# Altium schematic sheet Lights.SchDoc(Lights)
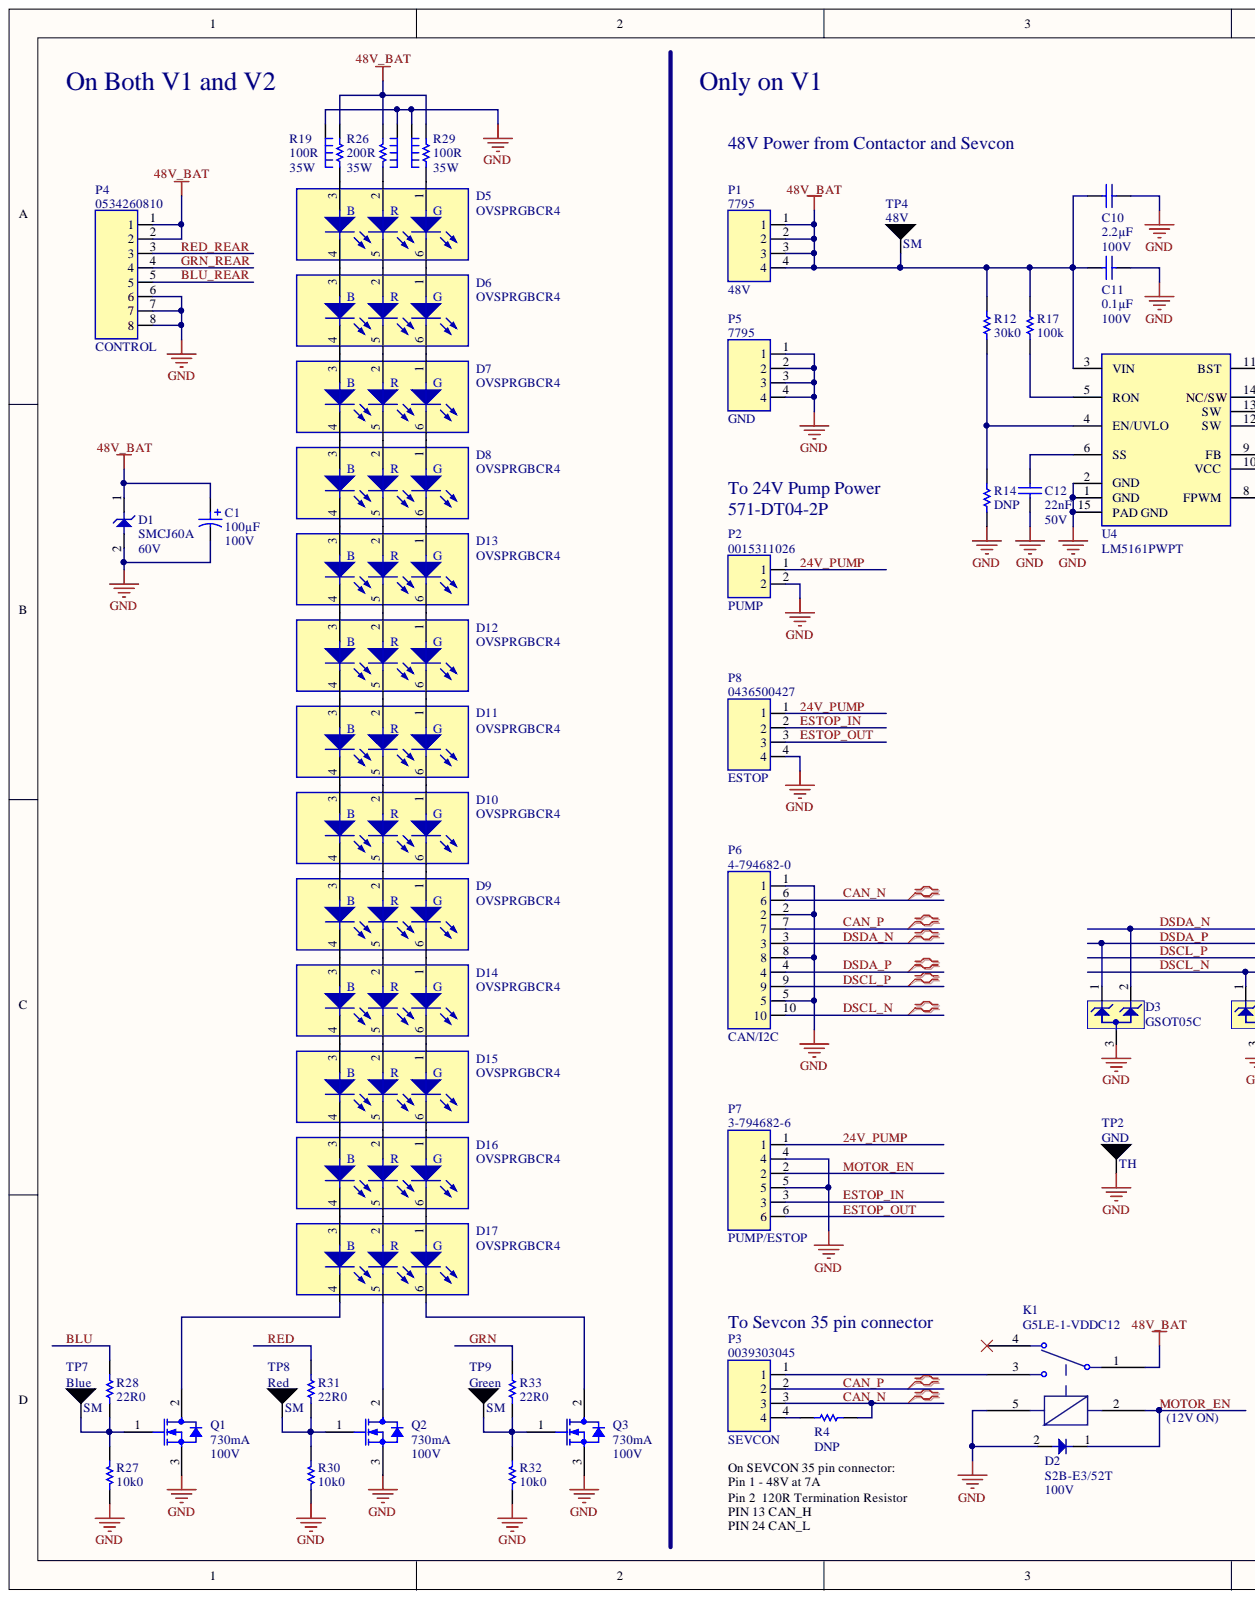
[diagram p0: Lights.SchDoc, left]
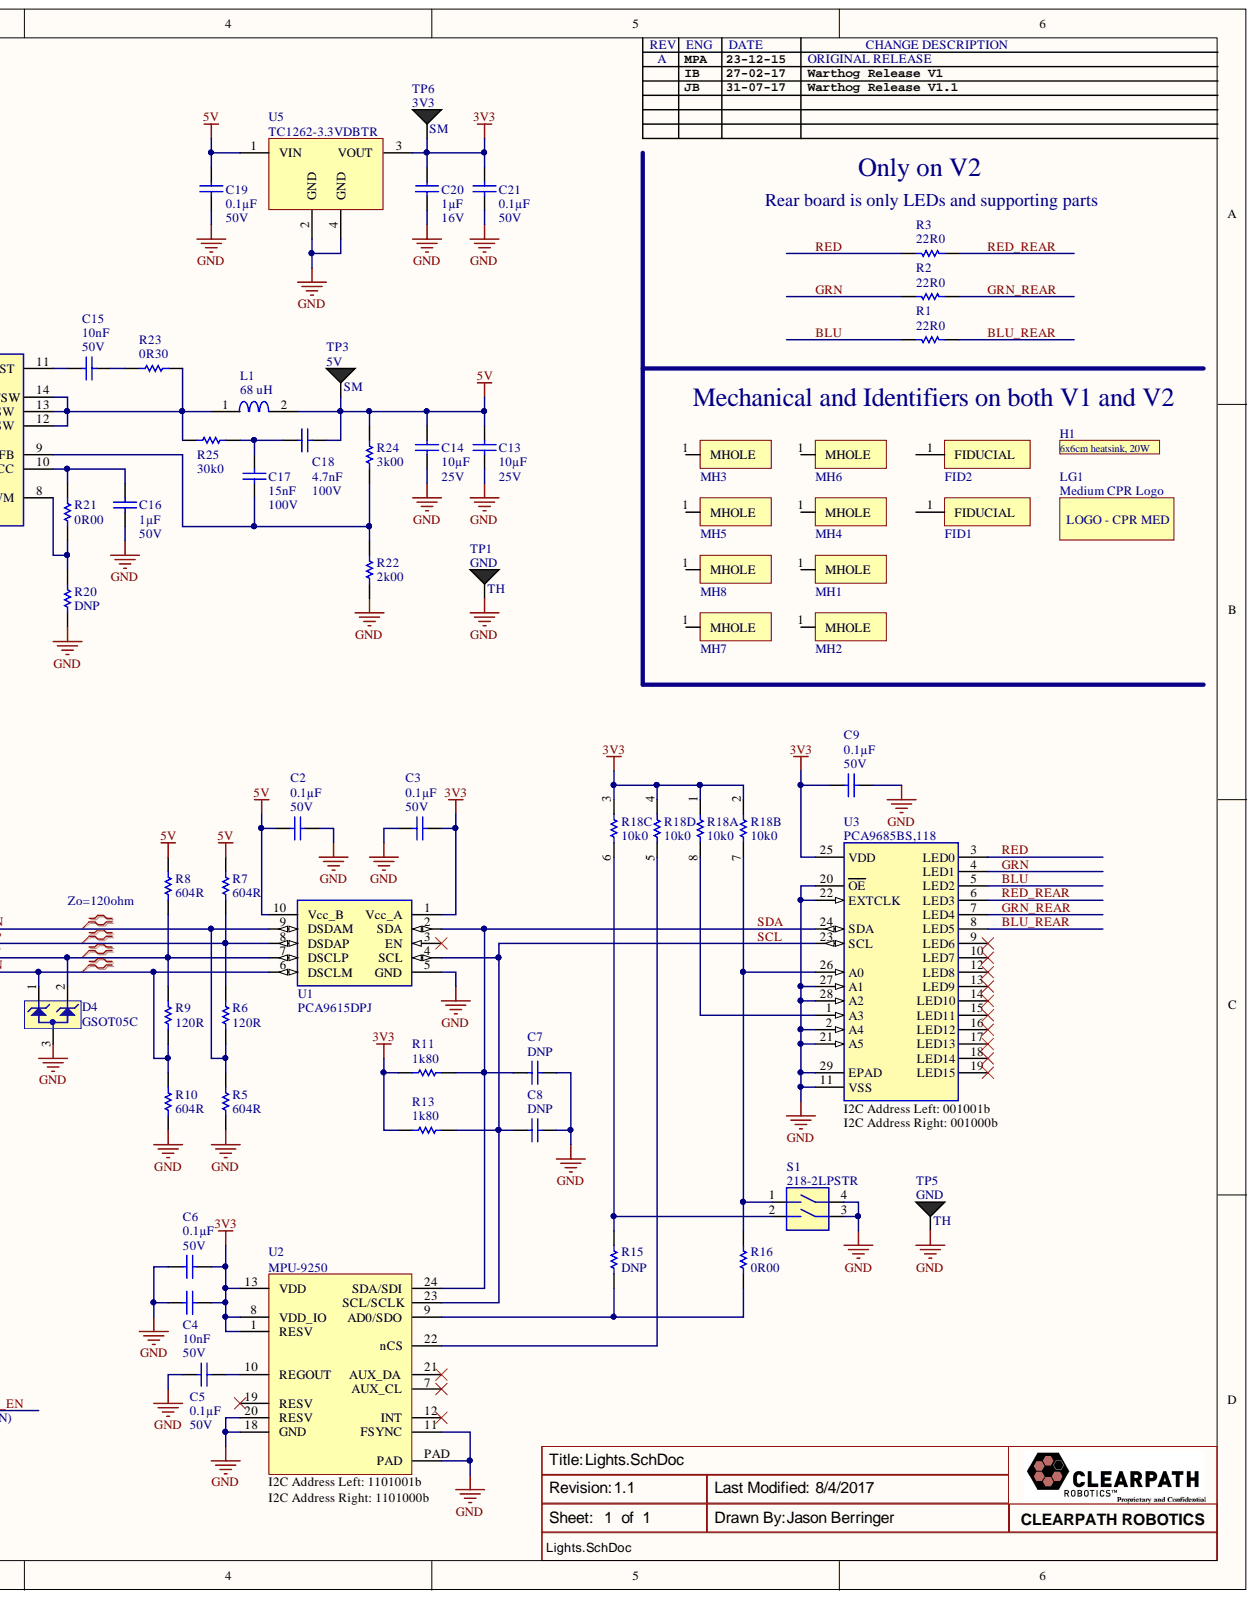
[diagram p1: Lights.SchDoc, right]

## Parts
C1  Voltage: 100V  Capacitance: 100µF  [PCAP KE0]  (hidden comment: Pcap)
C2  Voltage: 50V  Capacitance: 0.1µF  [CAP 0603]  (hidden comment: Cap)
C3  Voltage: 50V  Capacitance: 0.1µF  [CAP 0603]  (hidden comment: Cap)
C4  Capacitance: 10nF  [CAP 0603]  (hidden comment: Cap)
C5  Voltage: 50V  Capacitance: 0.1µF  [CAP 0603]  (hidden comment: Cap)
C6  Voltage: 50V  Capacitance: 0.1µF  [CAP 0603]  (hidden comment: Cap)
C7  Cap  [CAP 0603]  (value not drawn)
C8  Cap  [CAP 0603]  (value not drawn)
C9  Voltage: 50V  Capacitance: 0.1µF  [CAP 0603]  (hidden comment: Cap)
C10  Capacitance: 2.2µF  [CAP 1206]  (hidden comment: Cap)
C11  Voltage: 100V  Capacitance: 0.1µF  [CAP 0805]  (hidden comment: Cap)
C12  Voltage: 50V  Capacitance: 22nF  [CAP 0603]  (hidden comment: Cap)
C13  Voltage: 25V  Capacitance: 10µF  [CAP 1206]  (hidden comment: Cap)
C14  Voltage: 25V  Capacitance: 10µF  [CAP 1206]  (hidden comment: Cap)
C15  Voltage: 50V  Capacitance: 10nF  [CAP 0603]  (hidden comment: Cap)
C16  Voltage: 50V  Capacitance: 1µF  [CAP 0805]  (hidden comment: Cap)
C17  Voltage: 100V  Capacitance: 15nF  [CAP 0805]  (hidden comment: Cap)
C18  Voltage: 100V  Capacitance: 4.7nF  [CAP 0805]  (hidden comment: Cap)
C19  Voltage: 50V  Capacitance: 0.1µF  [CAP 0603]  (hidden comment: Cap)
C20  Voltage: 16V  Capacitance: 1µF  [CAP 0603]  (hidden comment: Cap)
C21  Voltage: 50V  Capacitance: 0.1µF  [CAP 0603]  (hidden comment: Cap)
D1  Voltage: 60V  [SMC_DO-214AB]  (hidden comment: TVS Diode)
D2  Voltage: 100V  [SMB_DO-214AA]  (hidden comment: Diode)
D3  GSOT05C  [SOT-23-3]
D4  GSOT05C  [SOT-23-3]
D5  OVSPRGBCR4  [OVSPRGBCR4]
D6  OVSPRGBCR4  [OVSPRGBCR4]
D7  OVSPRGBCR4  [OVSPRGBCR4]
D8  OVSPRGBCR4  [OVSPRGBCR4]
D9  OVSPRGBCR4  [OVSPRGBCR4]
D10  OVSPRGBCR4  [OVSPRGBCR4]
D11  OVSPRGBCR4  [OVSPRGBCR4]
D12  OVSPRGBCR4  [OVSPRGBCR4]
D13  OVSPRGBCR4  [OVSPRGBCR4]
D14  OVSPRGBCR4  [OVSPRGBCR4]
D15  OVSPRGBCR4  [OVSPRGBCR4]
D16  OVSPRGBCR4  [OVSPRGBCR4]
D17  OVSPRGBCR4  [OVSPRGBCR4]
FID1  Fiducial-1mm  [Fiducial-1mm]  (value not drawn)
FID2  Fiducial-1mm  [Fiducial-1mm]  (value not drawn)
H1  Component_1  [ATS-60003-C1-R0]  (value not drawn)
K1  G5LE-1-VDDC12  [G5LE-1-VDDC12]
L1  Inductance: 68 uH  [SRP7050TA-680M]  (hidden comment: Ind)
LG1  Medium CPR Logo  [Medium]
MH1  MOUNT_HOLE  [5.2mm mounting hole]  (value not drawn)
MH2  MOUNT_HOLE  [5.2mm mounting hole]  (value not drawn)
MH3  MOUNT_HOLE  [5.2mm mounting hole]  (value not drawn)
MH4  MOUNT_HOLE  [5.2mm mounting hole]  (value not drawn)
MH5  MOUNT_HOLE  [5.2mm mounting hole]  (value not drawn)
MH6  MOUNT_HOLE  [5.2mm mounting hole]  (value not drawn)
MH7  MOUNT_HOLE  [5.2mm mounting hole]  (value not drawn)
MH8  MOUNT_HOLE  [5.2mm mounting hole]  (value not drawn)
P1  48V  [7795]
P2  PUMP  [0015311026]
P3  SEVCON  [0039303045]
P4  CONTROL  [0534260810]
P5  GND  [7795]
P6  CAN/I2C  [4-794682-0]
P7  PUMP/ESTOP  [3-794682-6]
P8  ESTOP  [0436500427]
Q1  Voltage: 100V  Current: 730mA  [SOT89]  (hidden comment: MOSFET_SOT89)
Q2  Voltage: 100V  Current: 730mA  [SOT89]  (hidden comment: MOSFET_SOT89)
Q3  Voltage: 100V  Current: 730mA  [SOT89]  (hidden comment: MOSFET_SOT89)
R1  Resistance: 22R0  [RES 0603]  (hidden comment: Res)
R2  Resistance: 22R0  [RES 0603]  (hidden comment: Res)
R3  Resistance: 22R0  [RES 0603]  (hidden comment: Res)
R4  Res  [RES 0603]
R5  Resistance: 604R  [RES 0603]  (hidden comment: Res)
R6  Resistance: 120R  [RES 0603]  (hidden comment: Res)
R7  Resistance: 604R  [RES 0603]  (hidden comment: Res)
R8  Resistance: 604R  [RES 0603]  (hidden comment: Res)
R9  Resistance: 120R  [RES 0603]  (hidden comment: Res)
R10  Resistance: 604R  [RES 0603]  (hidden comment: Res)
R11  Resistance: 1k80  [RES 0603]  (hidden comment: Res)
R12  Resistance: 30k0  [RES 0603]  (hidden comment: Res)
R13  Resistance: 1k80  [RES 0603]  (hidden comment: Res)
R14  Res  [RES 0603]  (value not drawn)
R15  Res  [RES 0603]  (value not drawn)
R16  Resistance: 0R00  [RES 0603]  (hidden comment: Res)
R17  Resistance: 100k  [RES 0603]  (hidden comment: Res)
R18  Resistance: 10k0  [RESPACK 0804]  (hidden comment: Respack)  (parts A,B,C,D)
R19  Resistance: 100R  Power: 35W  [PWR263S-35]  (hidden comment: Res_D2PAK)
R20  Res  [RES 0603]  (value not drawn)
R21  Resistance: 0R00  [RES 0603]  (hidden comment: Res)
R22  Resistance: 2k00  [RES 0603]  (hidden comment: Res)
R23  Resistance: 0R30  [RES 0603]  (hidden comment: Res)
R24  Resistance: 3k00  [RES 0603]  (hidden comment: Res)
R25  Resistance: 30k0  [RES 0603]  (hidden comment: Res)
R26  Resistance: 200R  Power: 35W  [PWR263S-35]  (hidden comment: Res_D2PAK)
R27  Resistance: 10k0  [RES 0603]  (hidden comment: Res)
R28  Resistance: 22R0  [RES 0603]  (hidden comment: Res)
R29  Resistance: 100R  Power: 35W  [PWR263S-35]  (hidden comment: Res_D2PAK)
R30  Resistance: 10k0  [RES 0603]  (hidden comment: Res)
R31  Resistance: 22R0  [RES 0603]  (hidden comment: Res)
R32  Resistance: 10k0  [RES 0603]  (hidden comment: Res)
R33  Resistance: 22R0  [RES 0603]  (hidden comment: Res)
S1  218-2LPSTR  [218-2LPSTR]
TP1  GND  [TP_5028TH]
TP2  GND  [TP_5028TH]
TP3  5V  [TP_28SM]
TP4  48V  [TP_28SM]
TP5  GND  [TP_5028TH]
TP6  3V3  [TP_28SM]
TP7  Blue  [TP_28SM]
TP8  Red  [TP_28SM]
TP9  Green  [TP_28SM]
U1  PCA9615DPJ  [TSSOP-10N]
U2  MPU-9250  [QFN24 - 3x3x0.9 EXP PAD]
U3  PCA9685BS  [HVQFN-28-EP]
U4  LM5161PWPT  [TSSOP-14N-EP]
U5  PCB Component: TC1262-3.3VDBTR  [SOT223]  (hidden comment: FIX REGULATOR SOT223)

## Nets
3V3: C3.1 C4.1 C6.1 C9.1 C20.2 C21.2 R11.1 R13.1 R18.1 R18.2 R18.3 R18.4 TP6.1 U1.1(Vcc_A) U2.1(RESV) U2.8(VDD_IO) U2.13(VDD) U3.25(VDD) U5.3(VOUT)
5V: C2.1 C13.2 C14.2 C18.2 C19.2 L1.2 R7.2 R8.2 R24.1 TP3.1 U1.10(Vcc_B) U5.1(VIN)
48V_BAT: C1.1 C10.2 C11.1 D1.1 K1.1 P1.1 P1.2 P1.3 P1.4 P4.1 P4.2 R12.2 R17.2 R19.1 R26.1 R29.1 TP4.1 U4.3(VIN)
GND: C1.2 C2.2 C3.2 C4.2 C5.2 C6.2 C7.1 C8.1 C9.2 C10.1 C11.2 C12.1 C13.1 C14.1 C16.1 C19.1 C20.1 C21.1 D1.2 D2.2 D3.3 D4.3 K1.5 P2.2 P4.6 P4.7 P4.8 P5.1 P5.2 P5.3 P5.4 P6.1 P6.2 P6.5 P6.8 P7.4 P7.5 P8.4 Q1.3 Q2.3 Q3.3 R5.1 R10.1 R14.1 R19.3 R20.1 R22.2 R26.3 R27.2 R29.3 R30.2 R32.2 S1.3 S1.4 TP1.1 TP2.1 TP5.1 U1.5(GND) U2.11(FSYNC) U2.18(GND) U2.20(RESV) U2.PAD U3.2(A4) U3.11(VSS) U3.20(OE) U3.21(A5) U3.22(EXTCLK) U3.27(A1) U3.28(A2) U3.29(EPAD) U4.1(GND) U4.2(GND) U4.15(PAD_GND) U5.2(GND) U5.4(GND)
24V_PUMP: P2.1 P7.1 P8.1
BLU: R1.2 R28.2 U3.5(LED2)
BLU_REAR: P4.5 R1.1 U3.8(LED5)
CAN_N: P3.3 P6.6 R4.2
CAN_P: P3.2 P6.7
DSCL_N: D4.1 P6.10 R9.1 R10.2 U1.6(DSCLM)
DSCL_P: D4.2 P6.9 R8.1 R9.2 U1.7(DSCLP)
DSDA_N: D3.2 P6.3 R5.2 R6.1 U1.9(DSDAM)
DSDA_P: D3.1 P6.4 R6.2 R7.1 U1.8(DSDAP)
ESTOP_IN: P7.3 P8.2
ESTOP_OUT: P7.6 P8.3
GRN: R2.2 R33.2 U3.4(LED1)
GRN_REAR: P4.4 R2.1 U3.7(LED4)
MOTOR_EN: D2.1 K1.2 P7.2
RED: R3.2 R31.2 U3.3(LED0)
RED_REAR: P4.3 R3.1 U3.6(LED3)
SCL: C8.2 R13.2 U1.4(SCL) U2.23(SCL/SCLK) U3.23(SCL)
SDA: C7.2 R11.2 U1.2(SDA) U2.24(SDA/SDI) U3.24(SDA)
NetC5_1: C5.1 U2.10(REGOUT)
NetC12_2: C12.2 U4.6(SS)
NetC15_1: C15.1 R23.1
NetC15_2: C15.2 U4.11(BST)
NetC16_2: C16.2 R21.2 U4.10(VCC)
NetC17_1: C17.1 R22.1 R24.2 U4.9(FB)
NetC17_2: C17.2 C18.1 R25.2
NetD5_1: D5.1 R29.2
NetD5_2: D5.2 R26.2
NetD5_3: D5.3 R19.2
NetD5_4: D5.4 D6.3
NetD5_5: D5.5 D6.2
NetD5_6: D5.6 D6.1
NetD6_4: D6.4 D7.3
NetD6_5: D6.5 D7.2
NetD6_6: D6.6 D7.1
NetD7_4: D7.4 D8.3
NetD7_5: D7.5 D8.2
NetD7_6: D7.6 D8.1
NetD8_4: D8.4 D13.3
NetD8_5: D8.5 D13.2
NetD8_6: D8.6 D13.1
NetD9_1: D9.1 D10.6
NetD9_2: D9.2 D10.5
NetD9_3: D9.3 D10.4
NetD9_4: D9.4 D14.3
NetD9_5: D9.5 D14.2
NetD9_6: D9.6 D14.1
NetD10_1: D10.1 D11.6
NetD10_2: D10.2 D11.5
NetD10_3: D10.3 D11.4
NetD11_1: D11.1 D12.6
NetD11_2: D11.2 D12.5
NetD11_3: D11.3 D12.4
NetD12_1: D12.1 D13.6
NetD12_2: D12.2 D13.5
NetD12_3: D12.3 D13.4
NetD14_4: D14.4 D15.3
NetD14_5: D14.5 D15.2
NetD14_6: D14.6 D15.1
NetD15_4: D15.4 D16.3
NetD15_5: D15.5 D16.2
NetD15_6: D15.6 D16.1
NetD16_4: D16.4 D17.3
NetD16_5: D16.5 D17.2
NetD16_6: D16.6 D17.1
NetD17_4: D17.4 Q1.2
NetD17_5: D17.5 Q2.2
NetD17_6: D17.6 Q3.2
NetK1_3: K1.3 P3.1
NetL1_1: L1.1 R23.2 R25.1 U4.12(SW) U4.13(SW) U4.14(NC/SW)
NetP3_4: P3.4 R4.1
NetQ1_1: Q1.1 R27.1 R28.1 TP7.1
NetQ2_1: Q2.1 R30.1 R31.1 TP8.1
NetQ3_1: Q3.1 R32.1 R33.1 TP9.1
NetR12_1: R12.1 R14.2 U4.4(EN/UVLO)
NetR15_1: R15.1 R16.1 U2.9(AD0/SDO)
NetR15_2: R15.2 R18.6 S1.2
NetR16_2: R16.2 R18.7 S1.1 U3.26(A0)
NetR17_1: R17.1 U4.5(RON)
NetR18_5: R18.5 U2.22(nCS)
NetR18_8: R18.8 U3.1(A3)
NetR20_2: R20.2 R21.1 U4.8(FPWM)

Not connected: FID1 1; FID2 1; K1 4; MH1 1; MH2 1; MH3 1; MH4 1; MH5 1; MH6 1; MH7 1; MH8 1; U1 3(EN); U2 7(AUX_CL) 12(INT) 19(RESV) 21(AUX_DA); U3 9(LED6) 10(LED7) 12(LED8) 13(LED9) 14(LED10) 15(LED11) 16(LED12) 17(LED13) 18(LED14) 19(LED15)

## Library data of the parts
C1 = EMVY101ARA101MKE0S: Manufacturer: United Chemi-Con; Vendor PN: 565-2511-1-ND; Vendor: Digi-Key; Temperature Coefficient: -40 - 105C; ID: 29; Database Table Name: Capacitors; PCB Component: EMVY101ARA101MKE0S; Octopart Link: https://octopart.com/search?q=EMVY101ARA101MKE0S&start=0; Library Name: Parts.DbLib; Component Kind: Standard
C2 C3 C5 C6 C19 C21 = GRM188R71H104JA93D: Capacitor; Manufacturer: Murata Electronics North America; Vendor PN: 490-13406-1-ND; Vendor: Digi-Key; Temperature Coefficient: X7R; ID: 5; Database Table Name: Capacitors; PCB Component: GRM188R71H104JA93D; Library Name: Parts.DbLib; Component Kind: Standard
C4 = GRM188R71H103JA01J: Capacitor; Manufacturer: Murata Electronics North America; Voltage: 50V; Vendor PN: 490-11532-1-ND; Vendor: Digi-Key; Temperature Coefficient: X7R; ID: 6; Database Table Name: Capacitors; PCB Component: GRM188R71H103JA01J; Library Name: Parts.DbLib; Component Kind: Standard
C7 C8 = GRM188R71H221JA01D: Capacitor; Manufacturer: Murata Electronics North America; Voltage: 50V; Vendor PN: 490-12545-1-ND; Vendor: Digi-Key; Temperature Coefficient: X7R; ID: 8; Database Table Name: Capacitors; Capacitance: 220pF; PCB Component: GRM188R71H221JA01D; Library Name: Parts.DbLib; DNP: DNP; Component Kind: Standard
C9 = GRM188R71H104JA93D: Manufacturer: Murata Electronics North America; Vendor PN: 490-13406-1-ND; Vendor: Digi-Key; Temperature Coefficient: X7R; ID: 5; Database Table Name: Capacitors; PCB Component: GRM188R71H104JA93D; Octopart Link: https://octopart.com/search?q=GRM188R71H104JA93D&start=0; Library Name: Parts.DbLib; Component Kind: Standard
C10 = CC1206KKX7R0BB225: Manufacturer: Yageo; Voltage: 100V; Vendor PN: 311-3450-1-ND; Vendor: Digi-Key; Temperature Coefficient: X7R; ID: 32; Database Table Name: Capacitors; PCB Component: CC1206KKX7R0BB225; Library Name: Parts.DbLib; Component Kind: Standard
C11 = GRM21BR72A104KAC4L: Capacitor; Manufacturer: Murata Electronics North America; Vendor PN: 490-12005-1-ND; Vendor: Digi-Key; Temperature Coefficient: X7R; ID: 10; Database Table Name: Capacitors; PCB Component: GRM21BR72A104KAC4L; Library Name: Parts.DbLib; Component Kind: Standard
C12 = GRM188R71H223JA01D: Capacitor; Manufacturer: Murata Electronics North America; Vendor PN: 490-14377-1-ND; Vendor: Digi-Key; Temperature Coefficient: X7R; ID: 11; Database Table Name: Capacitors; PCB Component: GRM188R71H223JA01D; Library Name: Parts.DbLib; Component Kind: Standard
C13 C14 = GRM31CR71E106KA12L: Capacitor; Manufacturer: Murata Electronics North America; Vendor PN: 490-6518-1-ND; Vendor: Digi-Key; Temperature Coefficient: X7R; ID: 12; Database Table Name: Capacitors; PCB Component: GRM31CR71E106KA12L; Library Name: Parts.DbLib; Component Kind: Standard
C15 = GRM188R71H103JA01J: Capacitor; Manufacturer: Murata Electronics North America; Vendor PN: 490-11532-1-ND; Vendor: Digi-Key; Temperature Coefficient: X7R; ID: 6; Database Table Name: Capacitors; PCB Component: GRM188R71H103JA01J; Library Name: Parts.DbLib; Component Kind: Standard
C16 = CL21B105KBFNFNE: Capacitor; Manufacturer: Samsung Electro-Mechanics America, Inc.; Vendor PN: 1276-2928-1-ND; Vendor: Digi-Key; Temperature Coefficient: X7R; ID: 3; Database Table Name: Capacitors; PCB Component: CL21B105KBFNFNE; Library Name: Parts.DbLib; Component Kind: Standard
C17 = GRM21BR72A153KA01L: Capacitor; Manufacturer: Murata Electronics North America; Vendor PN: 490-1655-1-ND; Vendor: Digi-Key; Temperature Coefficient: X7R; ID: 14; Database Table Name: Capacitors; PCB Component: GRM21BR72A153KA01L; Library Name: Parts.DbLib; Component Kind: Standard
C18 = GRM219R72A472KA01D: Capacitor; Manufacturer: Murata Electronics North America; Vendor PN: 490-1646-1-ND; Vendor: Digi-Key; Temperature Coefficient: X7R; ID: 13; Database Table Name: Capacitors; PCB Component: GRM219R72A472KA01D; Library Name: Parts.DbLib; Component Kind: Standard
C20 = GRM188R61C105KA93D: Capacitor; Manufacturer: Murata Electronics North America; Vendor PN: 490-1536-1-ND; Vendor: Digi-Key; Temperature Coefficient: X7R; ID: 18; Database Table Name: Capacitors; PCB Component: GRM188R61C105KA93D; Library Name: Parts.DbLib; Component Kind: Standard
D1 = SMCJ60A: Manufacturer: Bourns Inc.; Vendor PN: SMCJ60ABCT-ND; Vendor: Digi-Key; ID: 11; Database Table Name: Circuit Protection; Current: 15.5A; Type: TVS; PCB Component: SMCJ60A; Octopart Link: https://octopart.com/search?q=SMCJ60A&start=0; Library Name: Parts.DbLib; Component Kind: Standard
D2 = S2B-E3/52T: Genral purpose diode 100V 1.5A; Manufacturer: Vishay Semiconductor Diodes Division; Vendor PN: S2B-E3/52TGICT-ND; Vendor: Digi-Key; ID: 10; Database Table Name: Diodes; Type: Diode; PCB Component: S2B-E3/52T; Octopart Link: https://octopart.com/search?q=S2B-E3%2F52T; Library Name: Parts.DbLib; Current: 1.5A; Component Kind: Standard
D3 D4 = GSOT05C-E3-08: Dual TVS array 300W 5V; Manufacturer: Vishay Semiconductors; Vendor PN: GSOT05C-E3-08CT-ND; Vendor: Digi-Key; ID: 17; Database Table Name: Circuit Protection; Voltage: 5V; Type: TVS array; PCB Component: GSOT05C-E3-08; Octopart Link: https://octopart.com/search?q=GSOT05C-E3-08; Library Name: Parts.DbLib; Component Kind: Standard
D5-D17 = OVSPRGBCR4: RGB Power LED, 6x6mm SMD; Manufacturer: TT Electronics/Optek Technology; Voltage: 2.3 - 3.4V; Vendor PN: 365-1393-1-ND; Vendor: Digi-Key; Type: RGB LED; ID: 9; Database Table Name: Diodes; Current: 250mA; PCB Component: OVSPRGBCR4; Octopart Link: https://octopart.com/search?q=OVSPRGBCR4&start=0; Library Name: Parts.DbLib; Component Kind: Standard
FID1 FID2 = Fiducial-1mm: Fiducial Mark; Library Name: Fiducial Mark.SchLib; Component Kind: Standard
H1 = Component_1: Manufacturer: Advanced Thermal Solutions Inc; Vendor PN: ATS1379-ND; Vendor: Digikey; PCB Component: ATS-60003-C1-R0; Component Kind: Standard; Library Name: Adhesive.SchLib
K1 = G5LE-1-VDDC12: Manufacturer: Omron; Vendor PN: Z3672-ND; Vendor: Digikey; Version: 1; PCB Component: G5LE-1-VDDC12; Library Name: Omron Relays.SchLib; Component Kind: Standard
L1 = SRP7050TA-680M: Inductor; Manufacturer: Bourns Inc; Vendor PN: SRP7050TA-680MCT-ND; Vendor: Digi-Key; Tolerance: +/- 20%; Resistance: 445 mOhm; ID: 1; Database Table Name: Inductors, Coils; Current - Sat: 2A; PCB Component: SRP7050TA-680M; Library Name: Parts.DbLib; Component Kind: Standard
LG1 = Logo Medium: Logo - CPR Medium; Component Kind: Standard; Library Name: Logos.SchLib
MH1-MH8 = MOUNT_HOLE: PCB mounting hole; Library Name: Mounting Holes.SchLib; Component Kind: Standard
P1 P5 = 7795: PCB Mount 15A M4 terminal screw; Manufacturer: Keystone Electronics; Vendor PN: 36-7795-ND; Vendor: Digi-Key; ID: 10; Database Table Name: Connectors; Type: TERM SCREW M4 4 PIN PCB; PCB Component: 7795; Octopart Link: https://octopart.com/search?q=7795; Library Name: Parts.DbLib; Current: 15A; Component Kind: Standard
P2 = 0015311026: 2 Position PCB mount vertical connector; Manufacturer: Molex, LLC; Vendor PN: WM1041-ND; Vendor: Digi-Key; ID: 15; Database Table Name: Connectors; Type: CONN HEADER 2POS .093 VERT TIN; PCB Component: 0015311026; Octopart Link: https://octopart.com/search?q=0015311026&start=0; Library Name: Parts.DbLib; Component Kind: Standard
P3 = 0039303045: Mini-Fit Jr. 4 position R/A PCB mount connector; Manufacturer: Molex, LLC; Voltage: 600V; Vendor PN: WM18447-ND; Vendor: Digi-Key; ID: 13; Database Table Name: Connectors; Current: 8A; Type: CONN HEADER 4POS 4.2MM R/A TIN; PCB Component: 0039303045; Octopart Link: https://octopart.com/search?q=0039303045&start=0; Library Name: Parts.DbLib; Component Kind: Standard
P4 = 0534260810: 8 Position R/A PCB mount connector; Manufacturer: Molex, LLC; Vendor PN: WM10109-ND; Vendor: Digi-Key; ID: 16; Database Table Name: Connectors; Type: 2.5 WTB WAFER ASSY RA W/KINK; PCB Component: 0534260810; Octopart Link: https://octopart.com/search?q=0534260810&start=0; Library Name: Parts.DbLib; Component Kind: Standard
P6 = 4-794682-0: Micro Mate-N-Lok 10 position 2 row PCB mount connector; Manufacturer: TE Connectivity; Voltage: 250V; Vendor PN: A123165-ND; Vendor: Digi-Key; ID: 12; Database Table Name: Connectors; Type: CONN HEADER 10POS DL VERT GOLD; PCB Component: 4-794682-0; Octopart Link: https://octopart.com/search?q=4-794682-0&start=0; Library Name: Parts.DbLib; Component Kind: Standard
P7 = 3-794682-6: Micro Mate-N-Lok 6 position 2 row PCB mount connector; Manufacturer: TE Connectivity; Voltage: 250V; Vendor PN: A121588-ND; Vendor: Digi-Key; ID: 11; Database Table Name: Connectors; Type: CONN HEADER PIN 6POS VERT GOLD; PCB Component: 3-794682-6; Octopart Link: https://octopart.com/search?q=3-794682-6&start=0; Library Name: Parts.DbLib; Component Kind: Standard
P8 = 0436500427: Micro-Fit 4 position vertical PCB mount connector; Manufacturer: Molex, LLC; Vendor PN: WM10663-ND; Vendor: Digi-Key; ID: 14; Database Table Name: Connectors; Type: MICROFIT 3.0 SR VERT TH PEG TIN; PCB Component: 0436500427; Octopart Link: https://octopart.com/search?q=0436500427&start=0; Library Name: Parts.DbLib; Component Kind: Standard
Q1-Q3 = TN2510N8-G: N-Channel MOSFET 100V 730mA; Manufacturer: Microchip Technology; Vendor PN: TN2510N8-GCT-ND; Vendor: Digi-Key; ID: 8; Database Table Name: Discrete Semiconductors; Type: N-Channel; PCB Component: TN2510N8-G; Octopart Link: https://octopart.com/search?q=TN2510N8-G&start=0; Library Name: Parts.DbLib; Component Kind: Standard
R1-R3 R28 R31 R33 = RC0603FR-0722RL: Manufacturer: Yageo; Vendor PN: 311-22.0HRCT-ND; Vendor: Digi-Key; Tolerance: +/- 1%; Power: 1/10W; ID: 17; Database Table Name: Resistors; PCB Component: RC0603FR-0722RL; Octopart Link: https://octopart.com/search?q=RC0603FR-0722RL&start=0; Library Name: Parts.DbLib; Component Kind: Standard
R4 R15 = RC0603FR-070RL: Manufacturer: Yageo; Vendor PN: 311-0.0HRCT-ND; Vendor: Digi-Key; Tolerance: +/- 1%; Resistance: 0R00; Power: 1/10W; ID: 9; Database Table Name: Resistors; PCB Component: RC0603FR-070RL; Octopart Link: https://octopart.com/search?q=RC0603FR-070RL; Library Name: Parts.DbLib; DNP: DNP; Component Kind: Standard
R5 R7 R8 R10 = RC0603FR-07604RL: Resistor; Manufacturer: Yageo; Vendor PN: 311-604HRCT-ND; Vendor: Digi-Key; Tolerance: +/- 1%; Power: 1/10W; ID: 5; Database Table Name: Resistors; PCB Component: RC0603FR-07604RL; Library Name: Parts.DbLib; DNP: DNP; Component Kind: Standard
R6 R9 = RC0603FR-07120RL: Resistor; Manufacturer: Yageo; Vendor PN: 311-120HRCT-ND; Vendor: Digi-Key; Tolerance: +/- 1%; Power: 1/10W; ID: 4; Database Table Name: Resistors; PCB Component: RC0603FR-07120RL; Library Name: Parts.DbLib; DNP: DNP; Component Kind: Standard
R11 R13 = RC0603FR-071K8L: Resistor; Manufacturer: Yageo; Vendor PN: 311-1.80KHRCT-ND; Vendor: Digi-Key; Tolerance: +/- 1%; Power: 1/10W; ID: 18; Database Table Name: Resistors; PCB Component: RC0603FR-071K8L; Library Name: Parts.DbLib; DNP: DNP; Component Kind: Standard
R12 R25 = RC0603FR-0730KL: Resistor; Manufacturer: Yageo; Vendor PN: 311-30.0KHRCT-ND; Vendor: Digi-Key; Tolerance: +/- 1%; Power: 1/10W; ID: 13; Database Table Name: Resistors; PCB Component: RC0603FR-0730KL; Library Name: Parts.DbLib; Component Kind: Standard
R14 = RC0603FR-0710KL: Resistor; Manufacturer: Yageo; Vendor PN: 311-10.0KHRCT-ND; Vendor: Digi-Key; Tolerance: +/- 1%; Resistance: 10k0; Power: 1/10W; ID: 15; Database Table Name: Resistors; PCB Component: RC0603FR-0710KL; Library Name: Parts.DbLib; DNP: DNP; Component Kind: Standard
R16 = RC0603FR-070RL: Manufacturer: Yageo; Vendor PN: 311-0.0HRCT-ND; Vendor: Digi-Key; Tolerance: +/- 1%; Power: 1/10W; ID: 9; Database Table Name: Resistors; PCB Component: RC0603FR-070RL; Octopart Link: https://octopart.com/search?q=RC0603FR-070RL; Library Name: Parts.DbLib; Component Kind: Standard
R17 = RC0603FR-07100KL: Resistor; Manufacturer: Yageo; Vendor PN: 311-100KHRCT-ND; Vendor: Digi-Key; Tolerance: +/- 1%; Power: 1/10W; ID: 14; Database Table Name: Resistors; PCB Component: RC0603FR-07100KL; Library Name: Parts.DbLib; Component Kind: Standard
R18 = 741C083103JP: Manufacturer: CTS Resistor Products; Vendor PN: 741C083103JPCT-ND; Vendor: Digi-Key; Tolerance: +/- 5%; Power: 62.5mW; ID: 29; Database Table Name: Resistors; PCB Component: 741C083103JP; Octopart Link: https://octopart.com/search?q=741C083103JP&start=0; Library Name: Parts.DbLib; Component Kind: Standard
R19 R29 = PWR263S-35-1000J: 100 Ω +/-5% 35W SMD power resistor; Manufacturer: Bourns Inc.; Vendor PN: PWR263S-35-1000J-ND; Vendor: Digi-Key; ID: 52; Database Table Name: Resistors; Tolerance: +/- 5%; PCB Component: PWR263S-35-1000J; Octopart Link: https://octopart.com/search?q=pwr263S-35-1000J&start=0; Library Name: Parts.DbLib; Component Kind: Standard
R20 = RC0603FR-070RL: Resistor; Manufacturer: Yageo; Vendor PN: 311-0.0HRCT-ND; Vendor: Digi-Key; Tolerance: +/- 1%; Resistance: 0R00; Power: 1/10W; ID: 9; Database Table Name: Resistors; PCB Component: RC0603FR-070RL; Library Name: Parts.DbLib; DNP: DNP; Component Kind: Standard
R21 = RC0603FR-070RL: Resistor; Manufacturer: Yageo; Vendor PN: 311-0.0HRCT-ND; Vendor: Digi-Key; Tolerance: +/- 1%; Power: 1/10W; ID: 9; Database Table Name: Resistors; PCB Component: RC0603FR-070RL; Library Name: Parts.DbLib; Component Kind: Standard
R22 = RC0603FR-072KL: Resistor; Manufacturer: Yageo; Vendor PN: 311-2.00KHRCT-ND; Vendor: Digi-Key; Tolerance: +/- 1%; Power: 1/10W; ID: 12; Database Table Name: Resistors; PCB Component: RC0603FR-072KL; Library Name: Parts.DbLib; Component Kind: Standard
R23 = RUT1608FR300CS: Resistor; Manufacturer: Samsung Electro-Mechanics America Inc; Vendor PN: 1276-6168-1-ND; Vendor: Digi-Key; Tolerance: +/-5%; Power: 1/8W; ID: 28; Database Table Name: Resistors; PCB Component: RUT1608FR300CS; Library Name: Parts.DbLib; Component Kind: Standard
R24 = RC0603FR-073KL: Resistor; Manufacturer: Yageo; Vendor PN: 311-3.00KHRCT-ND; Vendor: Digi-Key; Tolerance: +/- 1%; Power: 1/10W; ID: 3; Database Table Name: Resistors; PCB Component: RC0603FR-073KL; Library Name: Parts.DbLib; Component Kind: Standard
R26 = PWR263S-35-2000J: 200 Ω +/-5% 35W SMD power resistor; Manufacturer: Bourns Inc.; Vendor PN: PWR263S-35-2000J-ND; Vendor: Digi-Key; ID: 53; Database Table Name: Resistors; Tolerance: +/- 5%; PCB Component: PWR263S-35-2000J; Octopart Link: https://octopart.com/search?q=PWR263S-35-2000J&start=0; Library Name: Parts.DbLib; Component Kind: Standard
R27 R30 R32 = RC0603FR-0710KL: Manufacturer: Yageo; Vendor PN: 311-10.0KHRCT-ND; Vendor: Digi-Key; Tolerance: +/- 1%; Power: 1/10W; ID: 15; Database Table Name: Resistors; PCB Component: RC0603FR-0710KL; Octopart Link: https://octopart.com/search?q=RC0603FR-0710KL&start=0; Library Name: Parts.DbLib; Component Kind: Standard
S1 = 218-2LPSTR: Dual position SPST SMD DIP switch; Manufacturer: CTS Electrocomponents; Voltage: 24 VDC; Vendor PN: CT3108CT-ND; Vendor: Digi-Key; ID: 3; Function: Off-On; Database Table Name: Switches; Current: 0.025 A; Circuit: 2 SPST-NO; PCB Component: 218-2LPSTR; Octopart Link: https://octopart.com/search?q=218-2LPSTR&start=0; Library Name: Parts.DbLib; Component Kind: Standard
TP1 TP2 TP5 = TP_5028TH: Test Point, 50x28 thru-hole; Library Name: Test Points.SchLib; Component Kind: Standard
TP3 TP4 TP6-TP9 = TP_28SM: Test Point, 28mil SM pad; Library Name: Test Points.SchLib; Component Kind: Standard
U1 = PCA9615DPJ: Differential I2C Bus Buffer; Manufacturer: NXP Semiconductors; Voltage: 2.3 - 5.5V; Vendor PN: 568-11484-1-ND; Vendor: Digi-Key; ID: 3; Database Table Name: Interface ICs; Type: Differential I2C Bus Buffer; Protocol: I2C; PCB Component: PCA9615DPJ; Library Name: Parts.DbLib; Component Kind: Standard
U2 = MPU-9250: 9-axis IMU MPU-9250; Vendor PN: 1428-1019-1-ND; Vendor: Digikey; Added by: MPa; PCB Component: MPU-9250; Library Name: MPU-9250.SchLib; Component Kind: Standard
U3 = PCA9685BS,118: 16 Channel, 12 Bit PWM I2C LED Controller; Manufacturer: NXP USA Inc.; Vendor PN: 568-5305-1-ND; Vendor: Digi-Key; Input Voltage Range: 2.3 - 5.5V; ID: 4; Database Table Name: Power ICs; Current: 25mA; Type: PWM LED Controller; PCB Component: PCA9685BS,118; Octopart Link: https://octopart.com/search?q=PCA9685BS%2C118&start=0; Library Name: Parts.DbLib; Component Kind: Standard
U4 = LM5161PWPT: Manufacturer: Texas Instruments; Vendor PN: 296-44860-1-ND; Vendor: Digi-Key; Output Voltage: 2 - 92V; Input Voltage Range: 4.5 - 100V; ID: 1; Database Table Name: Power ICs; Current: 1A; Type: Buck/Fly Buck; PCB Component: LM5161PWPT; Octopart Link: https://octopart.com/search?q=LM5161PWPT&start=0; Library Name: Parts.DbLib; Component Kind: Standard
U5 = FIXED REGULATOR SOT223: FIXED VOLTAGE REGULATOR SOT223; Manufacturer: Microchip; Vendor PN: TC1262-3.3VDBCT-ND; Vendor: Digikey; Library Name: Generic SOT223 Fixed Regulator.SchLib; Component Kind: Standard
